# Altium schematic sheet 7_Mosfets.SchDoc
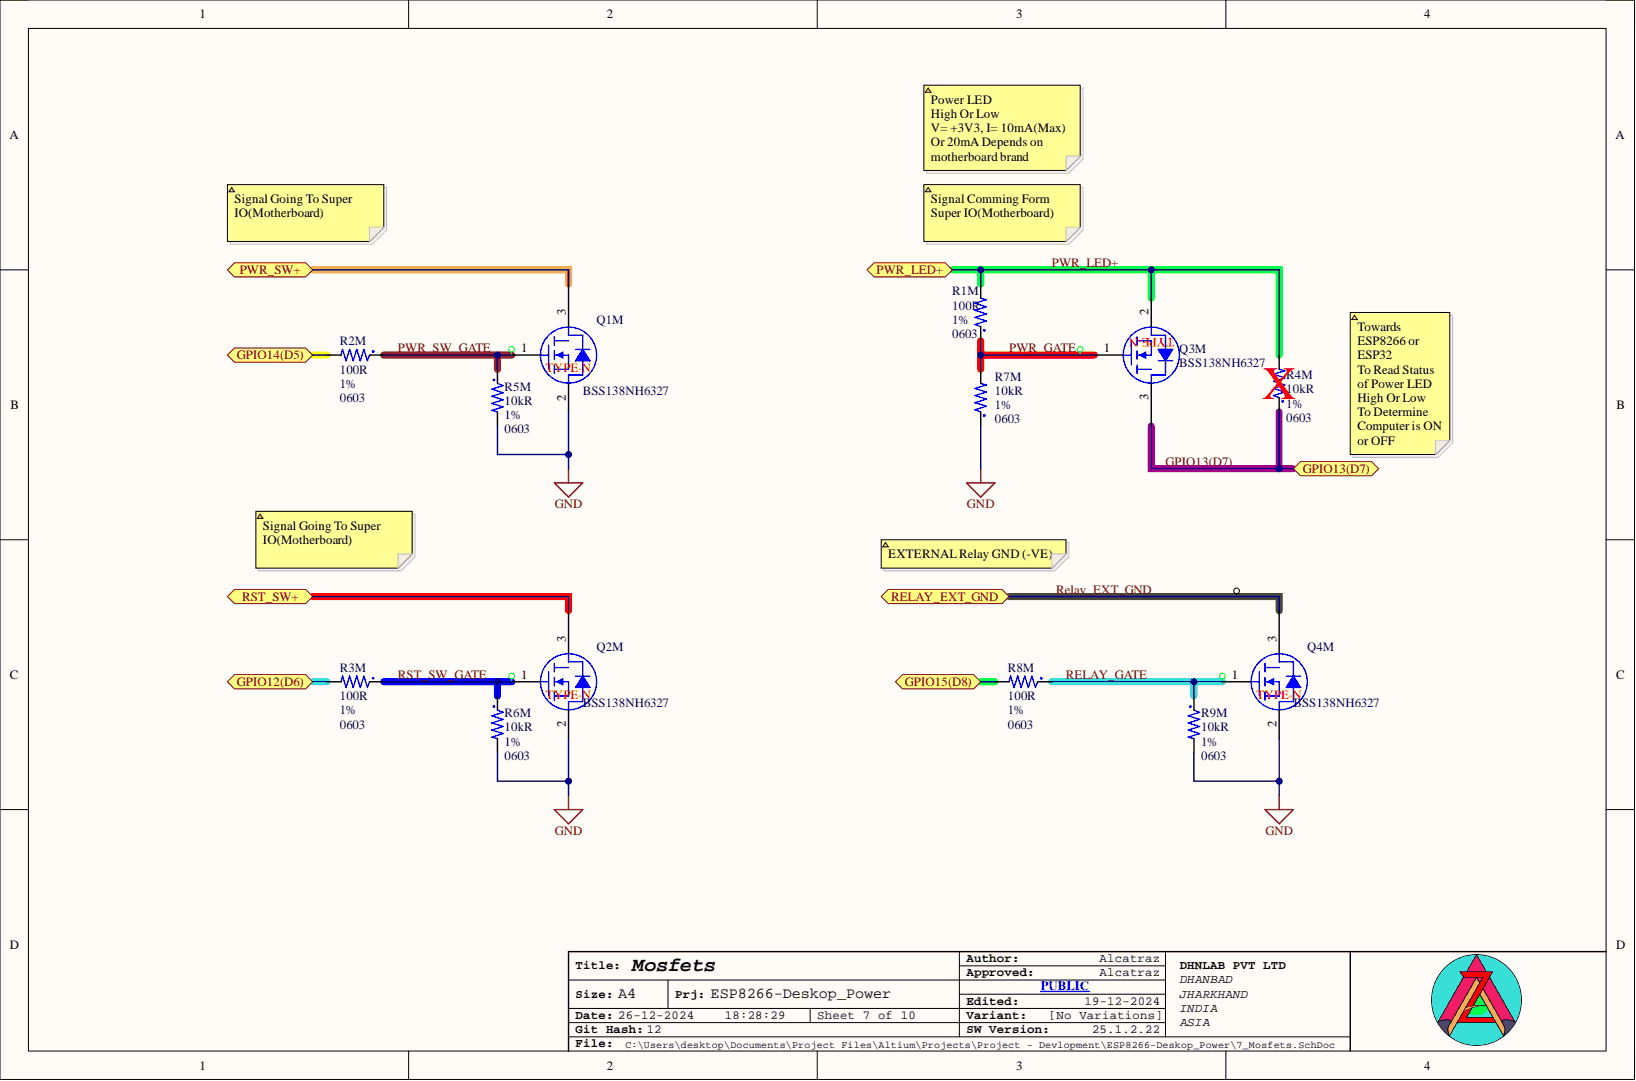
[diagram p0: 7_Mosfets.SchDoc]

## Parts
Q1M  BSS138NH6327  [SOT-23-3-IPC_C]
Q2M  BSS138NH6327  [SOT-23-3-IPC_C]
Q3M  BSS138NH6327  [SOT-23-3-IPC_C]
Q4M  BSS138NH6327  [SOT-23-3-IPC_C]
R1M  value 100R  Case Code (Imperial): 0603  Tolerance: 1%  [R0603-MFG]  (hidden comment: AC0603FR-07100RL)
R2M  value 100R  Tolerance: 1%  [R0603-MFG]  (hidden comment: AC0603FR-07100RL)
R3M  value 100R  Tolerance: 1%  [R0603-MFG]  (hidden comment: AC0603FR-07100RL)
R4M  value 10kR  Case Code (Imperial): 0603  Resistance Tolerance: 1%  [R0603-MFG]  (hidden comment: RC0603FR-0710KL)
R5M  value 10kR  Case Code (Imperial): 0603  Resistance Tolerance: 1%  [R0603-MFG]  (hidden comment: RC0603FR-0710KL)
R6M  value 10kR  Case Code (Imperial): 0603  Resistance Tolerance: 1%  [R0603-MFG]  (hidden comment: RC0603FR-0710KL)
R7M  value 10kR  Case Code (Imperial): 0603  Resistance Tolerance: 1%  [R0603-MFG]  (hidden comment: RC0603FR-0710KL)
R8M  value 100R  Tolerance: 1%  [R0603-MFG]  (hidden comment: AC0603FR-07100RL)
R9M  value 10kR  Case Code (Imperial): 0603  Resistance Tolerance: 1%  [R0603-MFG]  (hidden comment: RC0603FR-0710KL)

## Ports
GPIO12(D6), GPIO13(D7), GPIO14(D5), GPIO15(D8), PWR_LED+, PWR_SW+, RELAY_EXT_GND, RST_SW+

## Nets
GND: Q1M.2 Q2M.2 Q4M.2 R5M.2 R6M.2 R7M.1 R9M.2
GPIO12(D6): R3M.2 ; port GPIO12(D6)
GPIO13(D7): Q3M.3 R4M.1 ; port GPIO13(D7)
GPIO14(D5): R2M.2 ; port GPIO14(D5)
GPIO15(D8): R8M.2 ; port GPIO15(D8)
PWR_GATE: Q3M.1 R1M.1 R7M.2
PWR_LED+: Q3M.2 R1M.2 R4M.2 ; port PWR_LED+
PWR_SW+: Q1M.3 ; port PWR_SW+
PWR_SW_GATE: Q1M.1 R2M.1 R5M.1
Relay_EXT_GND: Q4M.3 ; port RELAY_EXT_GND
RELAY_GATE: Q4M.1 R8M.1 R9M.1
RST_SW+: Q2M.3 ; port RST_SW+
RST_SW_GATE: Q2M.1 R3M.1 R6M.1

## Library data of the parts
Q1M Q2M Q3M Q4M = BSS138NH6327: Single N-Channel 60 V 3.5 Ohm 1 nC SIPMOS® Small Signal Mosfet - SOT-23; Manufacturer: Infineon; Continuous Drain Current (ID): 230mA; Drain to Source Voltage (Vdss): 60V; Fall Time: 8.2ns; Gate to Source Voltage (Vgs): 20V; Input Capacitance: 41pF; Max Power Dissipation: 360mW; Rds On Max: 3.5R; Rise Time: 3ns; Threshold Voltage: 1V; Turn-Off Delay Time: 6.7ns; Turn-On Delay Time: 2.3ns; Voltage: 50V; Case/Package: TO-236-3; Component Kind: Standard; Height: 1mm; Length: 2.9mm; Library Name: Lib_Mosfet_NPN.IntLib; Manufacturer Part Number: BSS138NH6327; Max Operating Temperature: 150°C; Min Operating Temperature: -55°C; Mounting Technology: Surface Mount; Package Quantity: 3000; Packaging: Tape and Reel; Pins: 3; Pricing 1: 1=0.37, 10=0.281, 50=0.281, 100=0.159, 1000=0.081, 10000=0.056 (USD); Reach SVHC Compliant: No; RoHS: Yes; RoHS Compliant: Yes; Series: SIPMOS®; Stock 1: 795876; Supplier 1: Mouser; Supplier Part Number 1: 726-BSS138NH6327; Width: 1.3mm
R1M R2M R3M R8M = 100R: SMD Chip Resistor, 100 Ohm, ± 1%, 100 mW, 0603 [1608 Metric], Thick Film, General Purpose; Manufacturer: Yageo; Max Power Dissipation: 100mW; Temperature Coefficient: 100ppm/°C; Voltage Rating: 50V; Case Code (Metric): 1608; Case/Package: 0603; Category: Chip SMD Resistors; Component Kind: Standard; ComponentLink1Description: https://datasheet.ciiva.com/12576/pyu-ac-51-rohs-l-2-12576586.pdf?src-supplier=Mouser; ComponentLink1URL: https://datasheet.ciiva.com/12576/pyu-ac-51-rohs-l-2-12576586.pdf?src-supplier=Mouser; Contact Plating: Tin,Matte; Features: Moisture Resistant,Automotive AEC-Q200; Height: 0.45mm; Lead Free: 5697LeadFree; Length: 1.6mm; Library Name: Lib_Register_0603.IntLib; Manufacturer Part Number: AC0603FR-07100RL; Max Operating Temperature: 155°C; Min Operating Temperature: -55°C; Number of Terminations: 2; Packaging: Tape and Reel; Power Rating: 100mW; Pricing 1: 5000=0.0027, 50000=0.0025, 150000=0.0024, 375000=0.0023, 1000000=0.0022 (USD); Ratings: AEC-Q200; RoHS: Yes; RoHS Compliant: Yes; Series: AC; Stock 1: 1675000; Supplier 1: Future Electronics; Supplier Part Number 1: 2026405; Termination: SMD/SMT; Weight: 7.1E-05oz; Width: 0.8mm
  R2M R3M R8M: Case Code (Imperial): 0603
R4M R5M R6M R7M R9M = 10K: SMD Chip Resistor, 10 kOhm, ± 1%, 100 mW, 0603 [1608 Metric], Thick Film, General Purpose; Manufacturer: Yageo; Max Power Dissipation: 100mW; Temperature Coefficient: 100ppm/°C; Voltage Rating: 75V; Voltage Rating (DC): 50V; Case Code (Metric): 1608; Case/Package: 0603; Category: Chip SMD Resistors; Component Kind: Standard; Construction: Rectangular; Depth: 0.8mm; Features: Moisture Resistant; Height: 0.45mm; Lead Free: 5697LeadFree; Lead Pitch: 0.3mm; Length: 1.6mm; Library Name: Lib_Register_0603.IntLib; Manufacturer Part Number: RC0603FR-0710KL; Max Operating Temperature: 155°C; Military Standard: Not; Min Operating Temperature: -55°C; Number of Terminations: 2; Packaging: Tape and Reel; Pins: 2; Power Rating: 100mW; Pricing 1: 5000=0.0015, 50000=0.0014, 150000=0.0014, 375000=0.0013, 1000000=0.0012 (USD); Reach SVHC Compliant: No; Resistor Type: Thick Film; RoHS: Yes; RoHS Compliant: Yes; Series: RC; Stock 1: 44660000; Supplier 1: Future Electronics; Supplier Part Number 1: 4023214; Termination: SMD/SMT; Tolerance: 1%; Weight: 7.1E-05oz; Width: 0.8mm
